# Altium schematic sheet USB_CAMERA.SchDoc(USB_CAMERA)
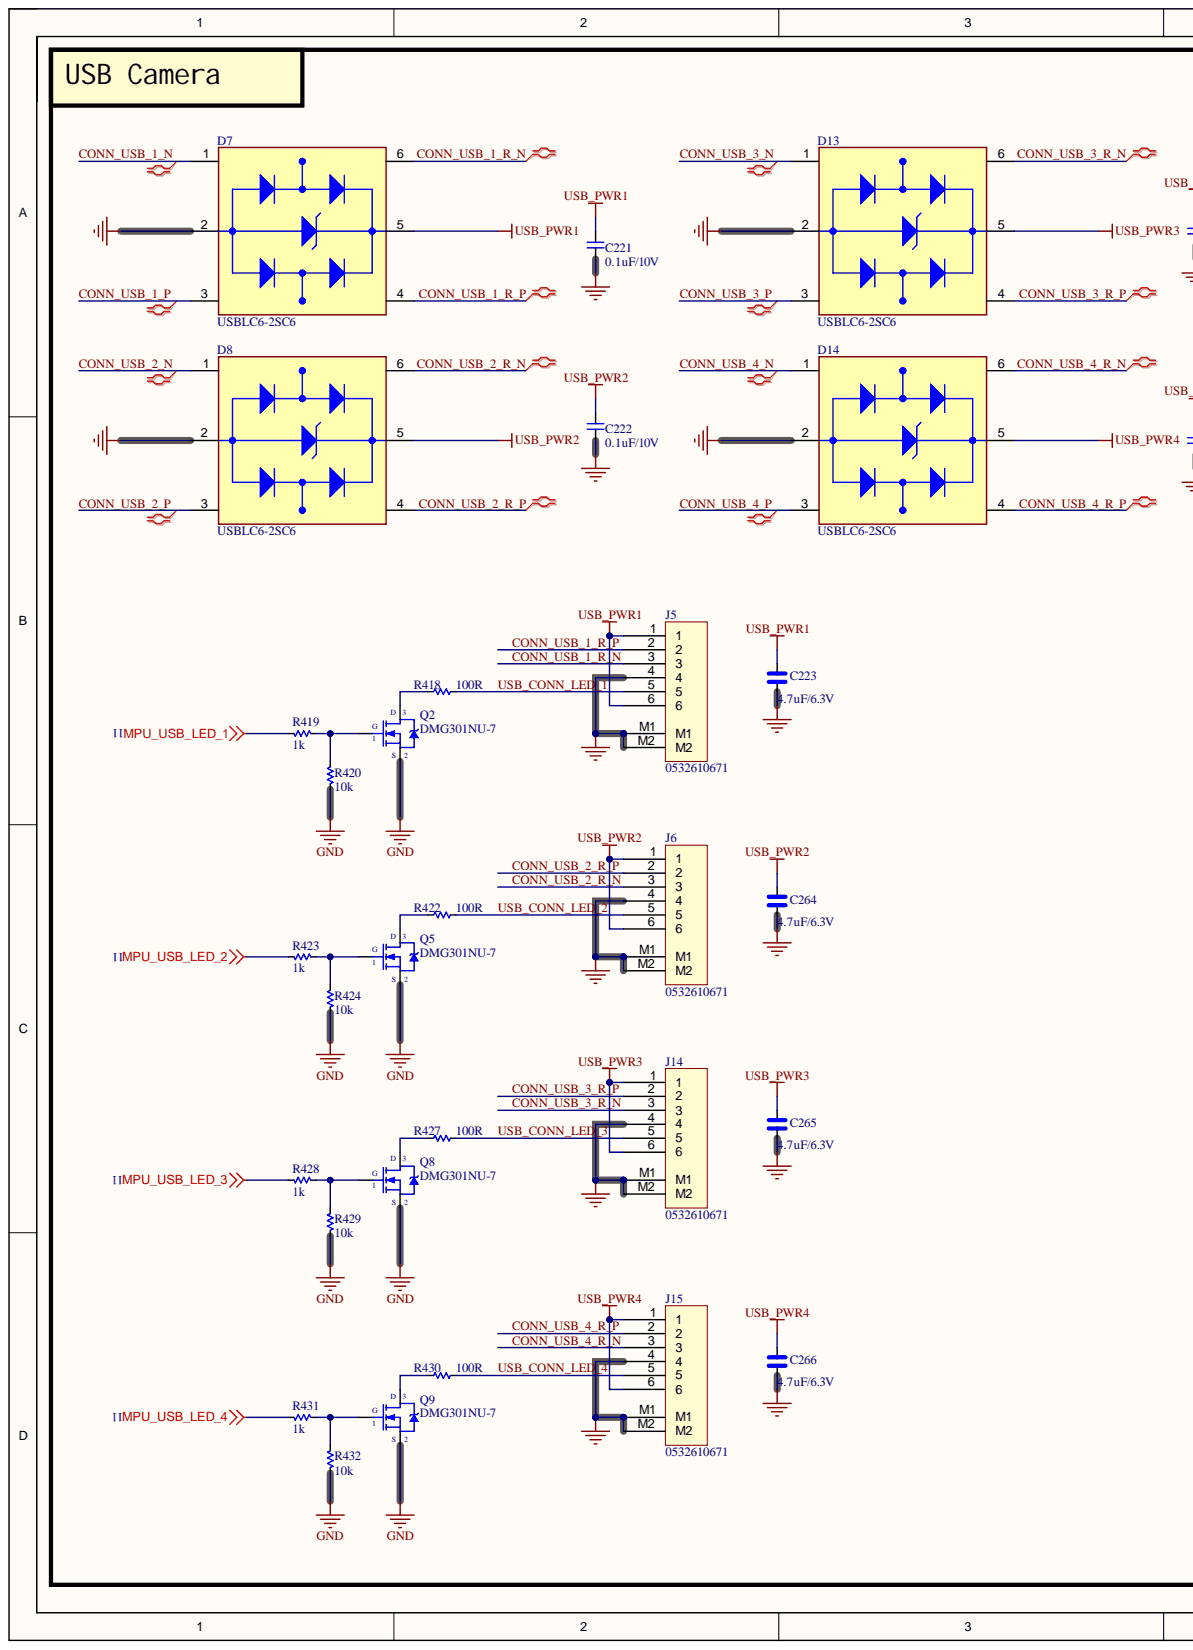
[diagram p0: USB_CAMERA.SchDoc, left]
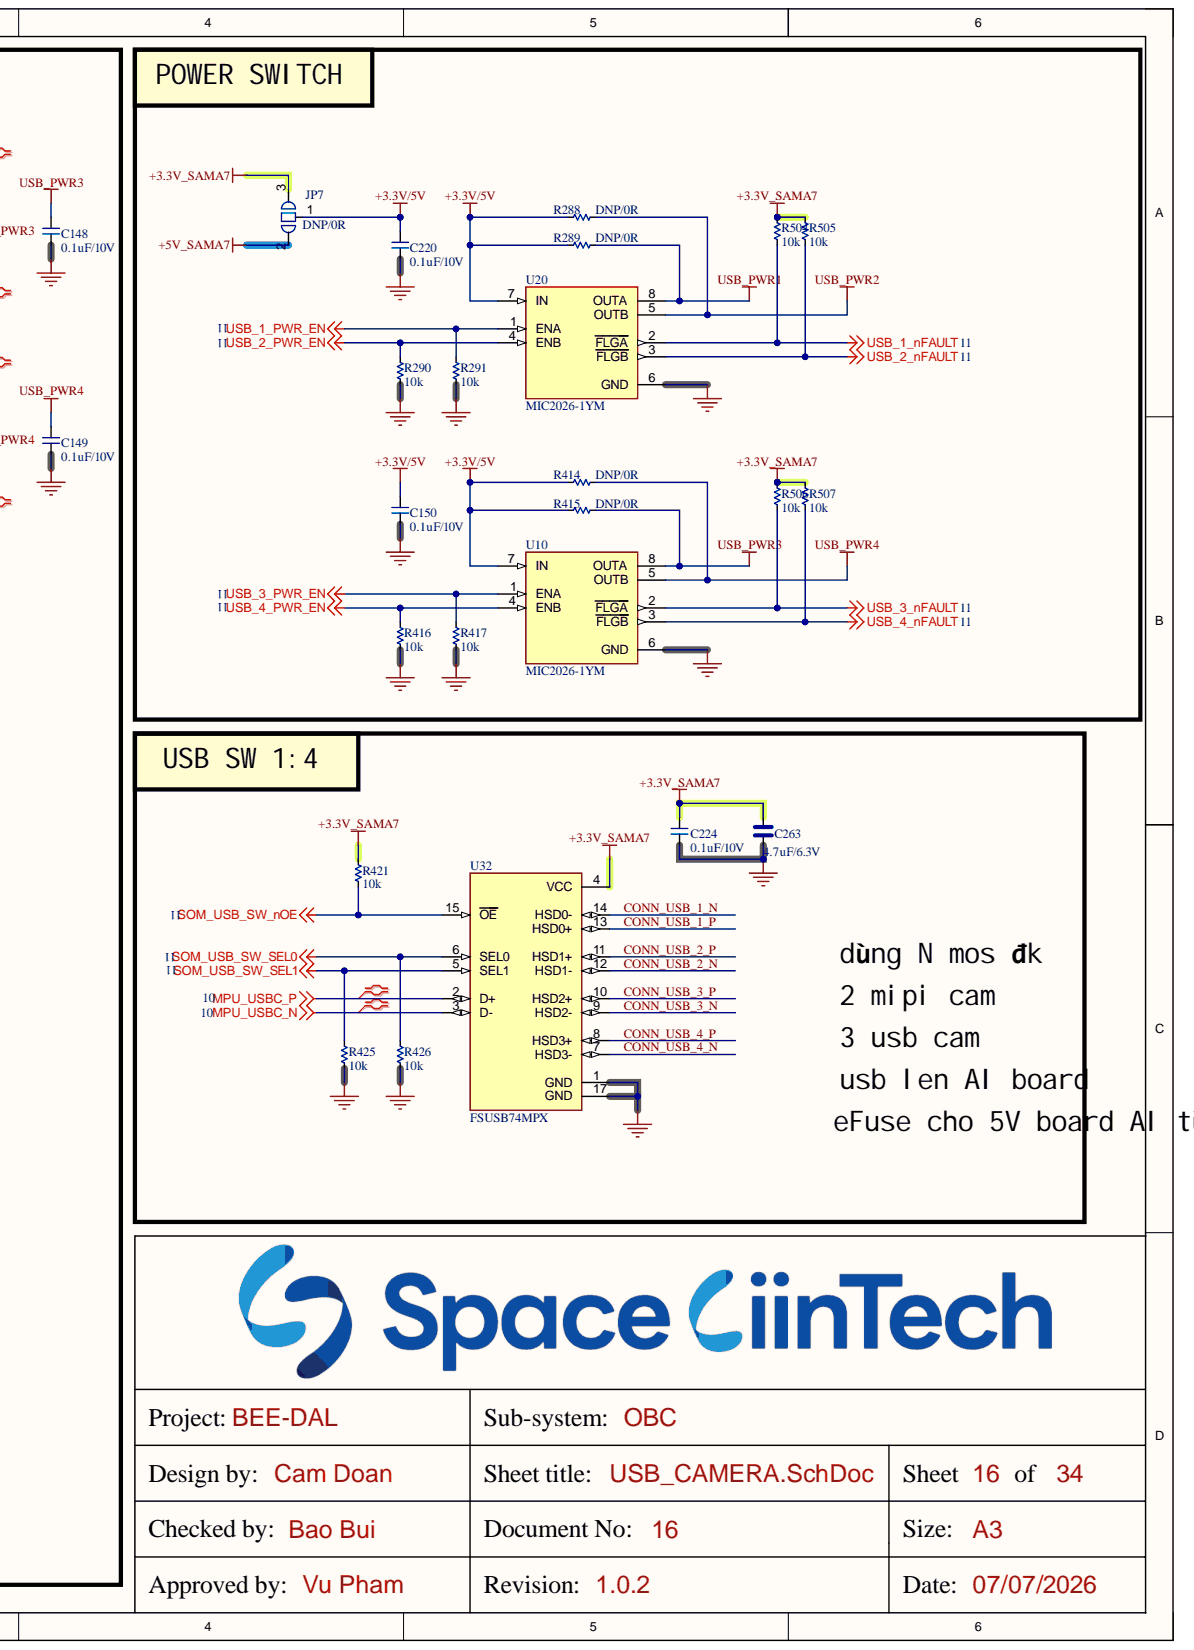
[diagram p1: USB_CAMERA.SchDoc, right]

## Parts
C148  0.1uF/10V  [CAP_C_1005X50_L]
C149  0.1uF/10V  [CAP_C_1005X50_L]
C150  0.1uF/10V  [CAP_C_1005X50_L]
C220  0.1uF/10V  [CAP_C_1005X50_L]
C221  0.1uF/10V  [CAP_C_1005X50_L]
C222  0.1uF/10V  [CAP_C_1005X50_L]
C223  4.7uF/6.3V  [CAP_C_1005X60]
C224  0.1uF/10V  [CAP_C_1005X60]
C263  4.7uF/6.3V  [CAP_C_1005X60]
C264  4.7uF/6.3V  [CAP_C_1005X60]
C265  4.7uF/6.3V  [CAP_C_1005X60]
C266  4.7uF/6.3V  [CAP_C_1005X60]
D7  USBLC6-2SC6  [SOT95P280X145-6N]
D8  USBLC6-2SC6  [SOT95P280X145-6N]
D13  USBLC6-2SC6  [SOT95P280X145-6N]
D14  USBLC6-2SC6  [SOT95P280X145-6N]
J5  0532610671  [MOLEX_0532610671]
J6  0532610671  [MOLEX_0532610671]
J14  0532610671  [MOLEX_0532610671]
J15  0532610671  [MOLEX_0532610671]
JP7  DNP/0R  [JUMPER_1-2_1608X60]
Q2  DMG301NU-7  [SOT95P240X120-3N]
Q5  DMG301NU-7  [SOT95P240X120-3N]
Q8  DMG301NU-7  [SOT95P240X120-3N]
Q9  DMG301NU-7  [SOT95P240X120-3N]
R288  DNP/0R  [RES_C_1005x30_L]
R289  DNP/0R  [RES_C_1005x30_L]
R290  10k  [RES_C_1005x30_L]
R291  10k  [RES_C_1005x30_L]
R414  DNP/0R  [RES_C_1005x30_L]
R415  DNP/0R  [RES_C_1005x30_L]
R416  10k  [RES_C_1005x30_L]
R417  10k  [RES_C_1005x30_L]
R418  100R  [RES_C_1005x30_L]
R419  1k  [RES_C_1005x30_L]
R420  10k  [RES_C_1005x30_L]
R421  10k  [RES_C_1005X40]
R422  100R  [RES_C_1005x30_L]
R423  1k  [RES_C_1005x30_L]
R424  10k  [RES_C_1005x30_L]
R425  10k  [RES_C_1005X40]
R426  10k  [RES_C_1005X40]
R427  100R  [RES_C_1005x30_L]
R428  1k  [RES_C_1005x30_L]
R429  10k  [RES_C_1005x30_L]
R430  100R  [RES_C_1005x30_L]
R431  1k  [RES_C_1005x30_L]
R432  10k  [RES_C_1005x30_L]
R504  10k  [RES_C_1005x30_L]
R505  10k  [RES_C_1005x30_L]
R506  10k  [RES_C_1005x30_L]
R507  10k  [RES_C_1005x30_L]
U10  MIC2026-1YM  [SOIC127P602X173-8N]
U20  MIC2026-1YM  [SOIC127P602X173-8N]
U32  FSUSB74MPX  [QFN50P300X300X80-17N]

## Nets
+3.3V/5V: C150.2 C220.2 JP7.1 R288.2 R289.2 R414.2 R415.2 U10.7(IN) U20.7(IN)
+3.3V_SAMA7: C224.2 C263.2 JP7.3 R421.1 R504.1 R505.1 R506.1 R507.1 U32.4(VCC)
+5V_SAMA7: JP7.2
GND: C148.1 C149.1 C150.1 C220.1 C221.1 C222.1 C223.2 C224.1 C263.1 C264.2 C265.2 C266.2 D7.2 D8.2 D13.2 D14.2 J5.4 J5.M1 J5.M2 J6.4 J6.M1 J6.M2 J14.4 J14.M1 J14.M2 J15.4 J15.M1 J15.M2 Q2.2 Q5.2 Q8.2 Q9.2 R290.2 R291.2 R416.2 R417.2 R420.1 R424.1 R425.2 R426.2 R429.1 R432.1 U10.6(GND) U20.6(GND) U32.1(GND) U32.17(GND)
MPU_USB_LED_1: R419.1
MPU_USB_LED_2: R423.1
MPU_USB_LED_3: R428.1
MPU_USB_LED_4: R431.1
MPU_USBC_N: U32.3(D-)
MPU_USBC_P: U32.2(D+)
SOM_USB_SW_nOE: R421.2 U32.15(OE)
SOM_USB_SW_SEL0: R426.1 U32.6(SEL0)
SOM_USB_SW_SEL1: R425.1 U32.5(SEL1)
USB_1_nFAULT: R504.2 U20.2(FLGA)
USB_1_PWR_EN: R291.1 U20.1(ENA)
USB_2_nFAULT: R505.2 U20.3(FLGB)
USB_2_PWR_EN: R290.1 U20.4(ENB)
USB_3_nFAULT: R506.2 U10.2(FLGA)
USB_3_PWR_EN: R417.1 U10.1(ENA)
USB_4_nFAULT: R507.2 U10.3(FLGB)
USB_4_PWR_EN: R416.1 U10.4(ENB)
USB_PWR1: C221.2 C223.1 D7.5 J5.1 J5.6 R289.1 U20.8(OUTA)
USB_PWR2: C222.2 C264.1 D8.5 J6.1 J6.6 R288.1 U20.5(OUTB)
USB_PWR3: C148.2 C265.1 D13.5 J14.1 J14.6 R415.1 U10.8(OUTA)
USB_PWR4: C149.2 C266.1 D14.5 J15.1 J15.6 R414.1 U10.5(OUTB)
CONN_USB_1_N: D7.1 U32.14(HSD0-)
CONN_USB_1_P: D7.3 U32.13(HSD0+)
CONN_USB_1_R_N: D7.6 J5.3
CONN_USB_1_R_P: D7.4 J5.2
CONN_USB_2_N: D8.1 U32.12(HSD1-)
CONN_USB_2_P: D8.3 U32.11(HSD1+)
CONN_USB_2_R_N: D8.6 J6.3
CONN_USB_2_R_P: D8.4 J6.2
CONN_USB_3_N: D13.1 U32.9(HSD2-)
CONN_USB_3_P: D13.3 U32.10(HSD2+)
CONN_USB_3_R_N: D13.6 J14.3
CONN_USB_3_R_P: D13.4 J14.2
CONN_USB_4_N: D14.1 U32.7(HSD3-)
CONN_USB_4_P: D14.3 U32.8(HSD3+)
CONN_USB_4_R_N: D14.6 J15.3
CONN_USB_4_R_P: D14.4 J15.2
USB_CONN_LED_1: J5.5 R418.2
USB_CONN_LED_2: J6.5 R422.2
USB_CONN_LED_3: J14.5 R427.2
USB_CONN_LED_4: J15.5 R430.2
NetQ2_1: Q2.1 R419.2 R420.2
NetQ2_3: Q2.3 R418.1
NetQ5_1: Q5.1 R423.2 R424.2
NetQ5_3: Q5.3 R422.1
NetQ8_1: Q8.1 R428.2 R429.2
NetQ8_3: Q8.3 R427.1
NetQ9_1: Q9.1 R431.2 R432.2
NetQ9_3: Q9.3 R430.1

## Library data of the parts
C148-C150 C220-C222 = _CAP_C_2: CAP; Component Kind: Standard; Library Name: LABLIB.SchLib
C223 C263-C266 = _CAP_C_High_2: CAP CHIP High Value; Component Kind: Standard; Library Name: LABLIB.SchLib
C224 = _CAP_C_2: CAP CHIP; Component Kind: Standard; Library Name: LABLIB.SchLib
D7 D8 D13 D14 = USBLC6-2SC6: 17V Clamp 5A (8/20Âµs) Ipp Tvs Diode Surface Mount SOT-23-6; MF: STMicroelectronics; Availability: In Stock; Check_prices: https://www.snapeda.com/parts/USBLC6-2SC6/STMicroelectronics/view-part/?ref=eda; Component Kind: Standard; Library Name: USBLC6-2SC6.IntLib; MP: USBLC6-2SC6; Package: SOT-23-6 STMicroelectronics; Price: None; Purchase-URL: https://pricing.snapeda.com/search/part/USBLC6-2SC6/?ref=eda; SnapEDA_Link: https://www.snapeda.com/parts/USBLC6-2SC6/STMicroelectronics/view-part/?ref=snap
J5 J6 J14 J15 = Header 6x1_2M: Component Kind: Standard; Library Name: LABLIB.SchLib
JP7 = JUMPER_1_2: Component Kind: Standard; Library Name: LABLIB.SchLib
Q2 Q5 Q8 Q9 = MOSFET_N_GSD: Component Kind: Standard; Library Name: LABLIB.SchLib
R288-R291 R414-R432 R504-R507 = _RES_B_2: RES; Component Kind: Standard; Library Name: LABLIB.SchLib
U10 U20 = IC_PWRSW_MIC2026-1YM: Power Switch/Driver 1:2 N-Channel 1.25A 8-SOIC; MF: Microchip; Check_prices: https://www.snapeda.com/parts/MIC2026-1YM/Microchip/view-part/?ref=eda; Component Kind: Standard; MP: MIC2026-1YM; Package: SO-8 Microchip; SnapEDA_Link: https://www.snapeda.com/parts/MIC2026-1YM/Microchip/view-part/?ref=snap; Library Name: LABLIB.SchLib
U32 = IC_USB_SW_FSUSB74MPX: USB Switch IC 1 Channel 16-MLP (3x3); MF: ON Semiconductor; Check_prices: https://www.snapeda.com/parts/FSUSB74MPX/Onsemi/view-part/?ref=eda; Component Kind: Standard; MP: FSUSB74MPX; Package: WQFN-16 ON Semiconductor; SnapEDA_Link: https://www.snapeda.com/parts/FSUSB74MPX/Onsemi/view-part/?ref=snap; Library Name: LABLIB.SchLib
